# Altium schematic sheet MCU.SchDoc(MCU)
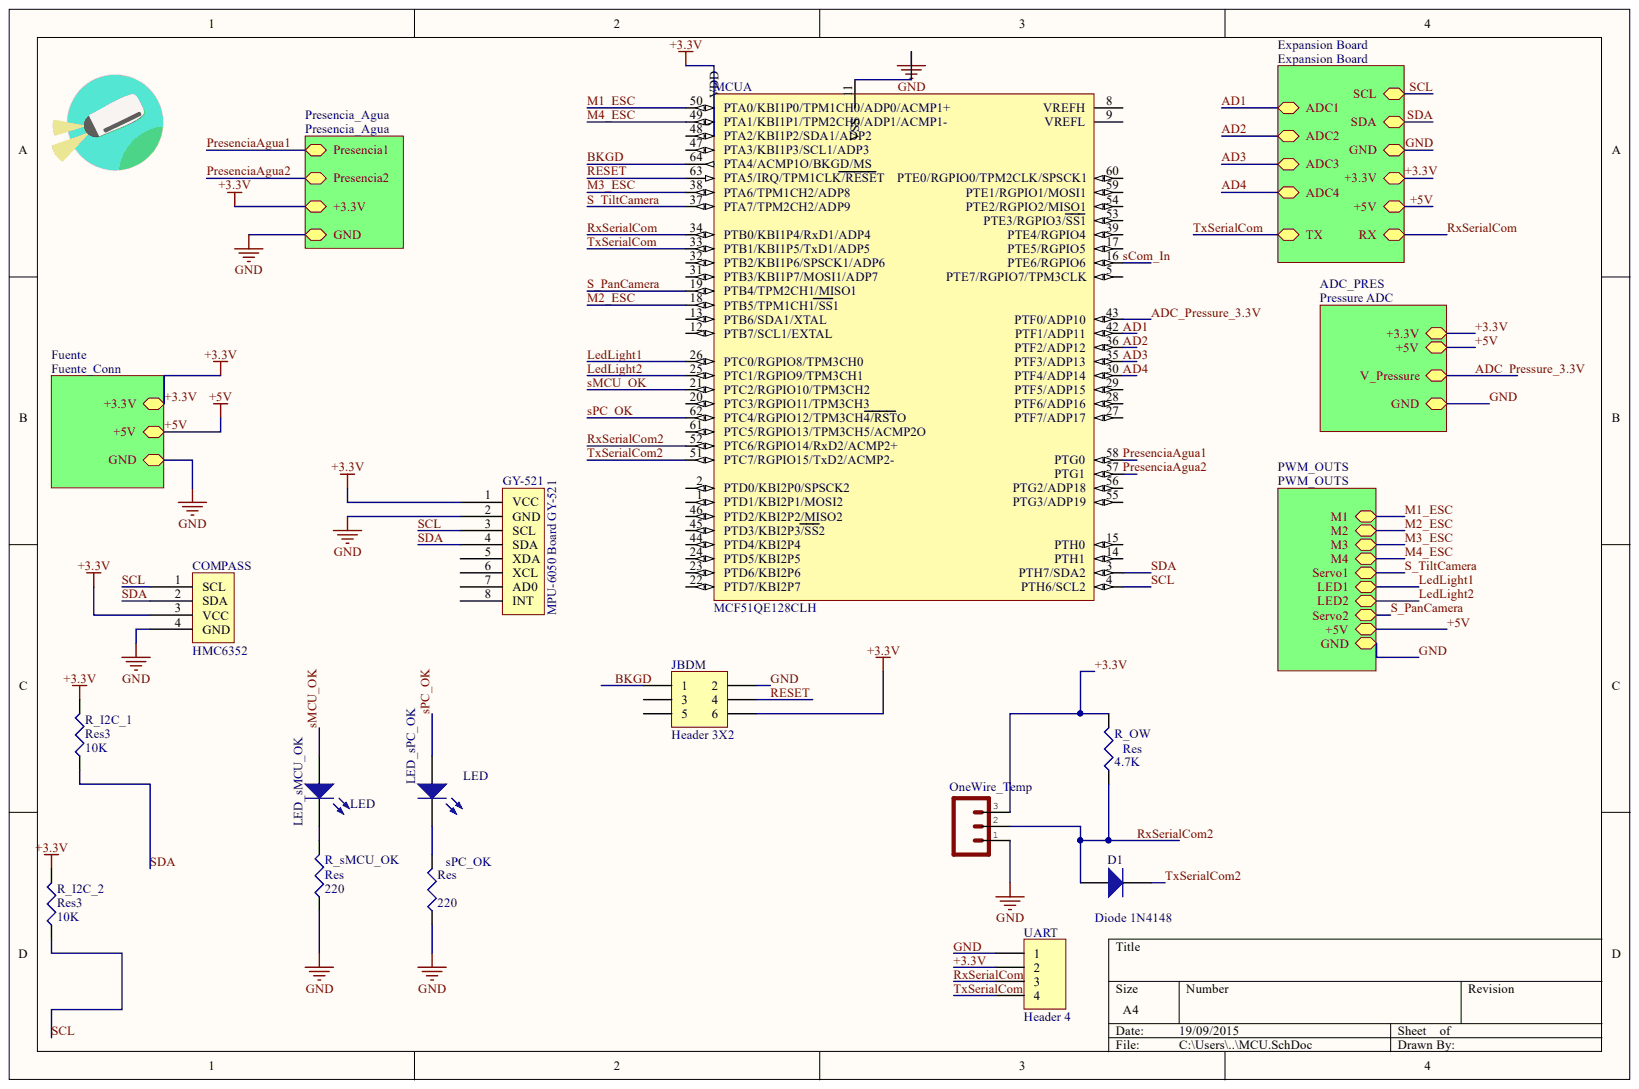
[diagram p0: MCU.SchDoc]

## Parts
COMPASS  HMC6352  [HMC6352_SF]
D1  Diode 1N4148  [DO-35]
GY-521  MPU-6050 Board GY-521  [GY-521]
JBDM  Header 3X2  [HDR2X3]
LED_sMCU_OK  LED  [3.2X1.6X1.1]
LED_sPC_OK  LED  [3.2X1.6X1.1]
MCU  MCF51QE128CLH  [840F-02_N]  (part A)
OneWire_Temp  (no value)  [HDR1X3]
R_I2C_1  Res3  value 10K  [RESC6332]
R_I2C_2  Res3  value 10K  [RESC6332]
R_OW  Res  value 4.7K  [RESC6332]
R_sMCU_OK  Res  value 220  [RESC6332]
sPC_OK  Res  value 220  [RESC6332]
UART  Header 4  [HDR1X4]

## Nets
+3.3V: COMPASS.3(VCC) GY-521.1(VCC) JBDM.6 MCU.6 OneWire_Temp.3 R_I2C_1.2 R_I2C_2.2 R_OW.2 UART.2
AD1: MCU.42(PTF1/ADP11)
AD2: MCU.36(PTF2/ADP12)
AD3: MCU.35(PTF3/ADP13)
AD4: MCU.30(PTF4/ADP14)
ADC_PRESSURE_3.3V: MCU.43(PTF0/ADP10)
BKGD: JBDM.1 MCU.64(PTA4/ACMP1O/BKGD/MS)
GND: COMPASS.4(GND) GY-521.2(GND) JBDM.2 MCU.11(VSS) OneWire_Temp.1 R_sMCU_OK.1 sPC_OK.1 UART.1
LEDLIGHT1: MCU.26(PTC0/RGPIO8/TPM3CH0)
LEDLIGHT2: MCU.25(PTC1/RGPIO9/TPM3CH1)
M1_ESC: MCU.50(PTA0/KBI1P0/TPM1CH0/ADP0/ACMP1+)
M2_ESC: MCU.18(PTB5/TPM1CH1/SS1)
M3_ESC: MCU.38(PTA6/TPM1CH2/ADP8)
M4_ESC: MCU.49(PTA1/KBI1P1/TPM2CH0/ADP1/ACMP1-)
PRESENCIAAGUA1: MCU.58(PTG0)
PRESENCIAAGUA2: MCU.57(PTG1)
RESET: JBDM.4 MCU.63(PTA5/IRQ/TPM1CLK/RESET)
RXSERIALCOM: MCU.34(PTB0/KBI1P4/RxD1/ADP4) UART.3
RXSERIALCOM2: D1.1 MCU.52(PTC6/RGPIO14/RxD2/ACMP2+) OneWire_Temp.2 R_OW.1
S_PANCAMERA: MCU.19(PTB4/TPM2CH1/MISO1)
S_TILTCAMERA: MCU.37(PTA7/TPM2CH2/ADP9)
SCL: COMPASS.1(SCL) GY-521.3(SCL) MCU.4(PTH6/SCL2) R_I2C_2.1
SCOM_IN: MCU.16(PTE6/RGPIO6)
SDA: COMPASS.2(SDA) GY-521.4(SDA) MCU.3(PTH7/SDA2) R_I2C_1.1
SMCU_OK: LED_sMCU_OK.1 MCU.21(PTC2/RGPIO10/TPM3CH2)
SPC_OK: LED_sPC_OK.1 MCU.62(PTC4/RGPIO12/TPM3CH4/RSTO)
TXSERIALCOM: MCU.33(PTB1/KBI1P5/TxD1/ADP5) UART.4
TXSERIALCOM2: D1.2 MCU.51(PTC7/RGPIO15/TxD2/ACMP2-)
NetGY-521_5: GY-521.5(XDA)
NetGY-521_6: GY-521.6(XCL)
NetGY-521_7: GY-521.7(AD0)
NetGY-521_8: GY-521.8(INT)
NetJBDM_3: JBDM.3
NetJBDM_5: JBDM.5
NetLED_sMCU_OK_2: LED_sMCU_OK.2 R_sMCU_OK.2
NetLED_sPC_OK_2: LED_sPC_OK.2 sPC_OK.2
NetMCU_1: MCU.1(PTD1/KBI2P1/MOSI2)
NetMCU_2: MCU.2(PTD0/KBI2P0/SPSCK2)
NetMCU_5: MCU.5(PTE7/RGPIO7/TPM3CLK)
NetMCU_8: MCU.8(VREFH)
NetMCU_9: MCU.9(VREFL)
NetMCU_12: MCU.12(PTB7/SCL1/EXTAL)
NetMCU_13: MCU.13(PTB6/SDA1/XTAL)
NetMCU_14: MCU.14(PTH1)
NetMCU_15: MCU.15(PTH0)
NetMCU_17: MCU.17(PTE5/RGPIO5)
NetMCU_20: MCU.20(PTC3/RGPIO11/TPM3CH3)
NetMCU_22: MCU.22(PTD7/KBI2P7)
NetMCU_23: MCU.23(PTD6/KBI2P6)
NetMCU_24: MCU.24(PTD5/KBI2P5)
NetMCU_27: MCU.27(PTF7/ADP17)
NetMCU_28: MCU.28(PTF6/ADP16)
NetMCU_29: MCU.29(PTF5/ADP15)
NetMCU_31: MCU.31(PTB3/KBI1P7/MOSI1/ADP7)
NetMCU_32: MCU.32(PTB2/KBI1P6/SPSCK1/ADP6)
NetMCU_39: MCU.39(PTE4/RGPIO4)
NetMCU_44: MCU.44(PTD4/KBI2P4)
NetMCU_45: MCU.45(PTD3/KBI2P3/SS2)
NetMCU_46: MCU.46(PTD2/KBI2P2/MISO2)
NetMCU_47: MCU.47(PTA3/KBI1P3/SCL1/ADP3)
NetMCU_48: MCU.48(PTA2/KBI1P2/SDA1/ADP2)
NetMCU_53: MCU.53(PTE3/RGPIO3/SS1)
NetMCU_54: MCU.54(PTE2/RGPIO2/MISO1)
NetMCU_55: MCU.55(PTG3/ADP19)
NetMCU_56: MCU.56(PTG2/ADP18)
NetMCU_59: MCU.59(PTE1/RGPIO1/MOSI1)
NetMCU_60: MCU.60(PTE0/RGPIO0/TPM2CLK/SPSCK1)
NetMCU_61: MCU.61(PTC5/RGPIO13/TPM3CH5/ACMP2O)
+5V:

## Library data of the parts
D1 = Diode 1N4148: High Conductance Fast Diode; Code_JEDEC: DO-204-AH; Component Kind: Standard; ComponentLink1Description: Manufacturer Link; ComponentLink1URL: http://www.fairchildsemi.com/; ComponentLink2Description: Datasheet; ComponentLink2URL: http://www.fairchildsemi.com/ds/1N%2F1N4148.pdf; DatasheetVersion: 1997; LatestRevisionDate: 17-Jul-2002; LatestRevisionNote: Re-released for DXP Platform.; Library Name: Miscellaneous Devices.IntLib; PackageDescription: Diode, Axial; Body 3.8 x 1.9 mm (LxDia. max), Lead Dia. 0.53 mm (max); PackageReference: DO-35; Published: 8-Jun-2000; Signal Integrity: 1N4148; Simulation: 1N4148; Publisher: Altium Limited
GY-521 = GY-521: Component Kind: Standard; Library Name: XSUB_COMP.SchLib
JBDM = Header 3X2: Header, 3-Pin, Dual row; Component Kind: Standard; LatestRevisionDate: 17-Jul-2002; LatestRevisionNote: Re-released for DXP Platform.; Library Name: Miscellaneous Connectors.IntLib; Signal Integrity: Connector; Publisher: Altium Limited
LED_sMCU_OK LED_sPC_OK = LED2: Typical RED, GREEN, YELLOW, AMBER GaAs LED; Component Kind: Standard; LatestRevisionDate: 17-Jul-2002; LatestRevisionNote: Re-released for DXP Platform.; Library Name: Miscellaneous Devices.IntLib; PackageDescription: SM LED; 2 Flat Leads; PackageReference: 3.2X1.6X1.1; PackageVersion: Feb-2002; Published: 8-Jun-2000; Simulation: LED2; Publisher: Altium Limited
MCU = MCF51QE128CLH: MCF51QE128 ColdFire V1 Microcontroller, 32-Bit, 128KB Flash, 8KB RAM, -40°C to 85°C, 64-Pin LQFP; Component Kind: Standard; ComponentLink1Description: Manufacturer URL; ComponentLink1URL: http://www.freescale.com/; ComponentLink2Description: Datasheet; ComponentLink2URL: http://cache.freescale.com/files/32bit/doc/data_sheet/MCF51QE128.pdf?fpsp=1&WT_TYPE=Data%20Sheets&WT_VENDOR=FREESCALE&WT_FILE_FORMAT=pdf&WT_ASSET=Documentation; DatasheetVersion: rev7, Oct-2008; Library Name: Freescale Semiconductor ColdFire MCU.IntLib; PackageDescription: 64-Pin Thin Quad Flat Pack, 10 x 10 mm Body, 0.5 mm Pitch; PackageReference: 840F-02; PackageVersion: rev6, Mar-2011
OneWire_Temp = M03-SCREW-5MM: STOREFRONT_ID: PRT-08433; Component Kind: Standard; Library Name: SparkFun-Connectors.IntLib
R_I2C_1 R_I2C_2 R_OW R_sMCU_OK sPC_OK = Res3: Resistor; Component Kind: Standard; LatestRevisionDate: 29-May-2009; LatestRevisionNote: IPC-7351 Footprint Added.; Library Name: Miscellaneous Devices.IntLib; PackageDescription: Chip Resistor; PackageReference: J1-0603; Published: 8-Jun-2000; Signal Integrity: Res; Simulation: RESISTOR; Publisher: Altium Limited
UART = Header 4: Header, 4-Pin; Component Kind: Standard; LatestRevisionDate: 17-Jul-2002; LatestRevisionNote: Re-released for DXP Platform.; Library Name: Miscellaneous Connectors.IntLib; Signal Integrity: Connector; Publisher: Altium Limited
COMPASS = HMC6352: Component Kind: Standard; Library Name: XSUB_COMP.SchLib
